# Altium schematic sheet CM001_V4_6.SchDoc(CM001_V4_6)
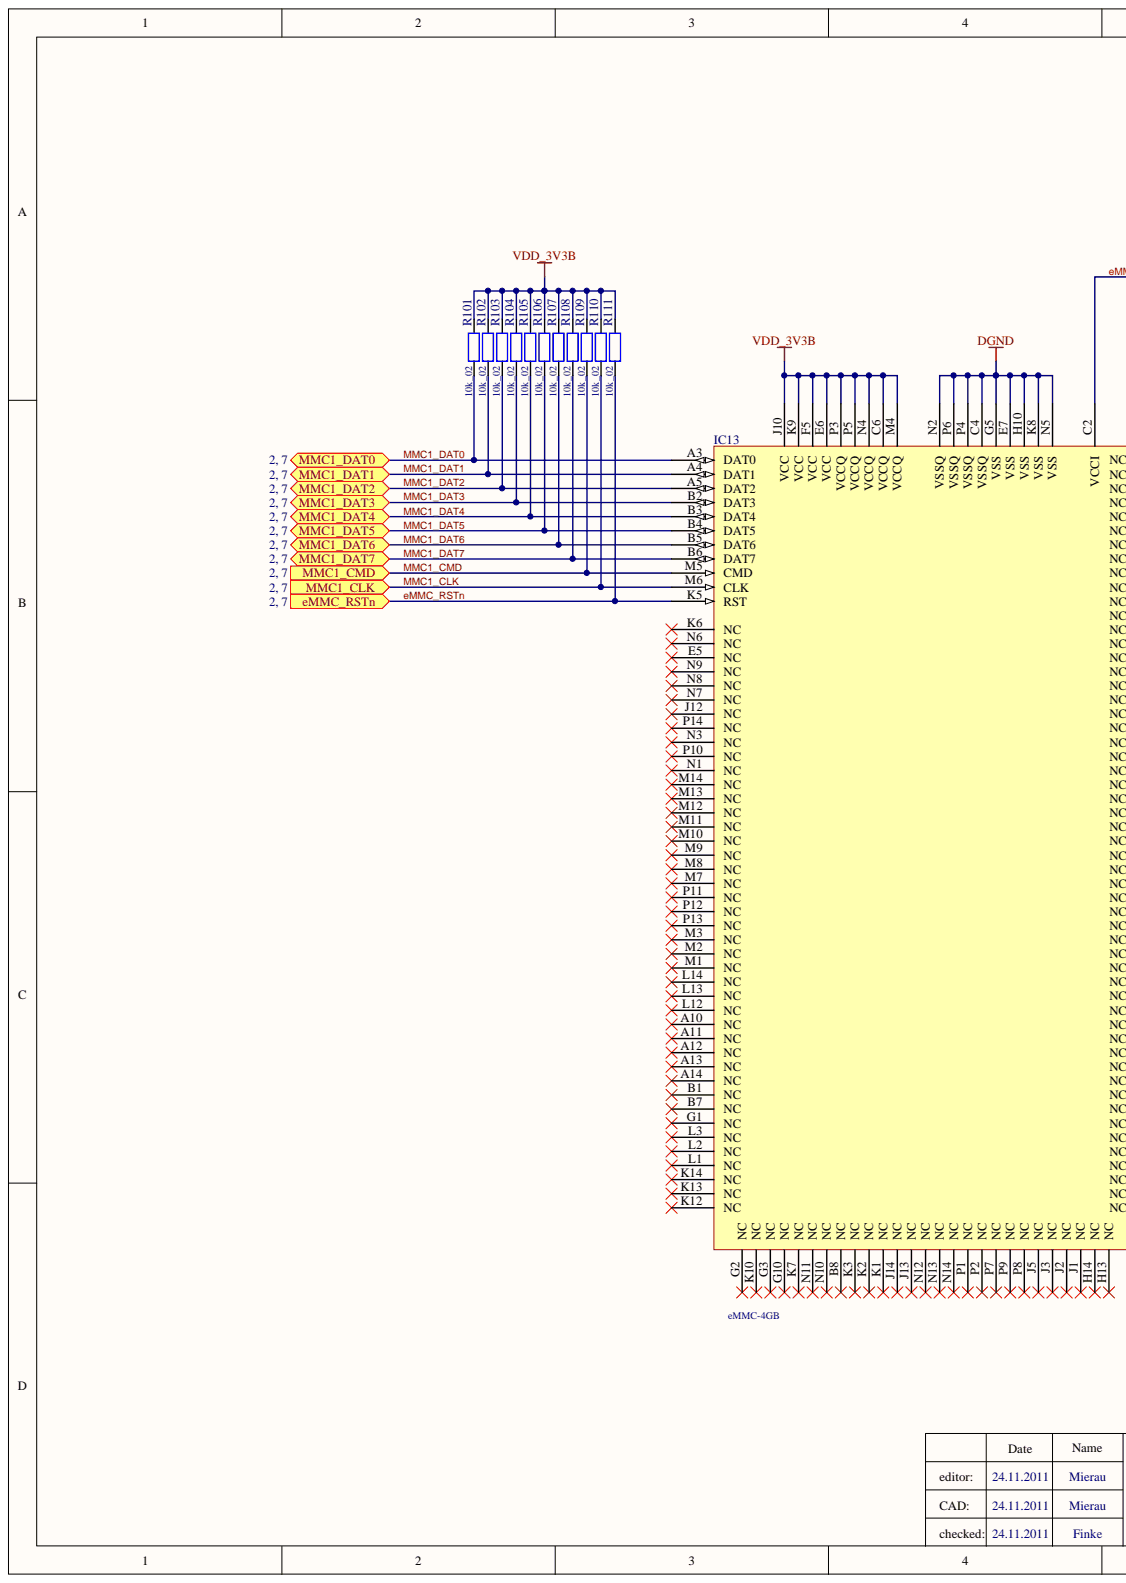
[diagram p0: CM001_V4_6.SchDoc, left]
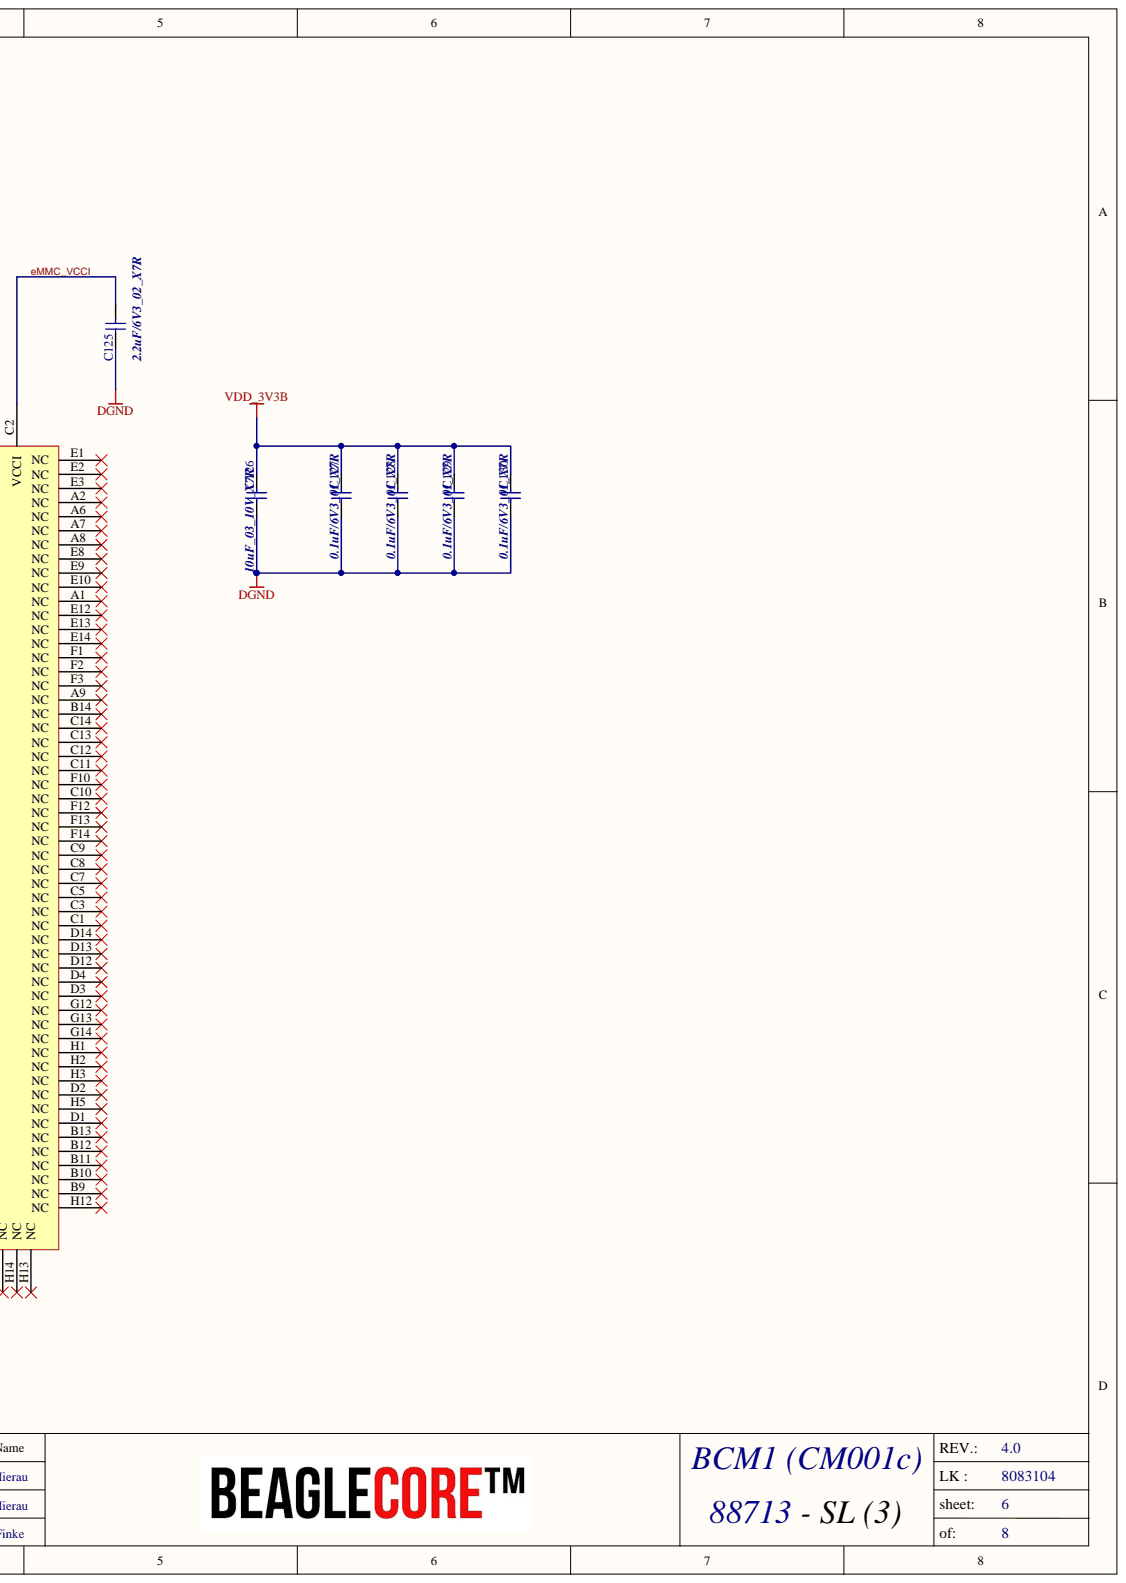
[diagram p1: CM001_V4_6.SchDoc, right]

## Parts
C125  2.2uF/6V3_02_X7R  [CC1005-0402]
C125  2.2uF/6V3_02_X7R  [CC1005-0402]
C126  10uF_03_10V_X7R  [CC1608-0603]
C126  10uF_03_10V_X7R  [CC1608-0603]
C127  0.1uF/6V3_01_X7R  [CC0603-0201]
C127
C128  0.1uF/6V3_01_X7R  [CC0603-0201]
C128
C129  0.1uF/6V3_01_X7R  [CC0603-0201]
C129
C130  0.1uF/6V3_01_X7R  [CC0603-0201]
C130
IC13  eMMC-4GB  [BGA153_P14_P5_11P5X13]
R101  10k_02  [CR1005-0402]
R102  10k_02  [CR1005-0402]
R103  10k_02  [CR1005-0402]
R104  10k_02  [CR1005-0402]
R105  10k_02  [CR1005-0402]
R106  10k_02  [CR1005-0402]
R107  10k_02  [CR1005-0402]
R108  10k_02  [CR1005-0402]
R109  10k_02  [CR1005-0402]
R110  10k_02  [CR1005-0402]
R111  10k_02  [CR1005-0402]

## Ports
eMMC_RSTn, MMC1_CLK, MMC1_CMD, MMC1_DAT0, MMC1_DAT1, MMC1_DAT2, MMC1_DAT3, MMC1_DAT4, MMC1_DAT5, MMC1_DAT6, MMC1_DAT7

## Nets
DGND: C125.1 C126.1 C127.1 C128.1 C129.1 C130.1 IC13.C4(VSSQ) IC13.E7(VSS) IC13.G5(VSS) IC13.H10(VSS) IC13.K8(VSS) IC13.N2(VSSQ) IC13.N5(VSS) IC13.P4(VSSQ) IC13.P6(VSSQ)
VDD_3V3B: C126.2 C127.2 C128.2 C129.2 C130.2 IC13.C6(VCCQ) IC13.E6(VCC) IC13.F5(VCC) IC13.J10(VCC) IC13.K9(VCC) IC13.M4(VCCQ) IC13.N4(VCCQ) IC13.P3(VCCQ) IC13.P5(VCCQ) R101.1 R102.1 R103.1 R104.1 R105.1 R106.1 R107.1 R108.1 R109.1 R110.1 R111.1
eMMC_RSTn: IC13.K5(RST) R111.2 ; port eMMC_RSTn
eMMC_VCCI: C125.2 IC13.C2(VCCI)
MMC1_CLK: IC13.M6(CLK) R110.2 ; port MMC1_CLK
MMC1_CMD: IC13.M5(CMD) R109.2 ; port MMC1_CMD
MMC1_DAT0: IC13.A3(DAT0) R101.2 ; port MMC1_DAT0
MMC1_DAT1: IC13.A4(DAT1) R102.2 ; port MMC1_DAT1
MMC1_DAT2: IC13.A5(DAT2) R103.2 ; port MMC1_DAT2
MMC1_DAT3: IC13.B2(DAT3) R104.2 ; port MMC1_DAT3
MMC1_DAT4: IC13.B3(DAT4) R105.2 ; port MMC1_DAT4
MMC1_DAT5: IC13.B4(DAT5) R106.2 ; port MMC1_DAT5
MMC1_DAT6: IC13.B5(DAT6) R107.2 ; port MMC1_DAT6
MMC1_DAT7: IC13.B6(DAT7) R108.2 ; port MMC1_DAT7

Not connected: IC13 A1(NC) A2(NC) A6(NC) A7(NC) A8(NC) A9(NC) A10(NC) A11(NC) A12(NC) A13(NC) A14(NC) B1(NC) B7(NC) B8(NC) B9(NC) B10(NC) B11(NC) B12(NC) B13(NC) B14(NC) C1(NC) C3(NC) C5(NC) C7(NC) C8(NC) C9(NC) C10(NC) C11(NC) C12(NC) C13(NC) C14(NC) D1(NC) D2(NC) D3(NC) D4(NC) D12(NC) D13(NC) D14(NC) E1(NC) E2(NC) E3(NC) E5(NC) E8(NC) E9(NC) E10(NC) E12(NC) E13(NC) E14(NC) F1(NC) F2(NC) F3(NC) F10(NC) F12(NC) F13(NC) F14(NC) G1(NC) G2(NC) G3(NC) G10(NC) G12(NC) G13(NC) G14(NC) H1(NC) H2(NC) H3(NC) H5(NC) H12(NC) H13(NC) H14(NC) J1(NC) J2(NC) J3(NC) J5(NC) J12(NC) J13(NC) J14(NC) K1(NC) K2(NC) K3(NC) K6(NC) K7(NC) K10(NC) K12(NC) K13(NC) K14(NC) L1(NC) L2(NC) L3(NC) L12(NC) L13(NC) L14(NC) M1(NC) M2(NC) M3(NC) M7(NC) M8(NC) M9(NC) M10(NC) M11(NC) M12(NC) M13(NC) M14(NC) N1(NC) N3(NC) N6(NC) N7(NC) N8(NC) N9(NC) N10(NC) N11(NC) N12(NC) N13(NC) N14(NC) P1(NC) P2(NC) P7(NC) P8(NC) P9(NC) P10(NC) P11(NC) P12(NC) P13(NC) P14(NC)

## Library data of the parts
C125 = C2.2uF/6V3_02_X7R: Capacitor 0402 6V3 X7R; S/T: SMD; Art-Nr.: 08.0002.; erstellt von: Fin; Value: 2.2uF/6V3_02_X7R; Simulation: CAP; Signal Integrity: Cap; Revision: July-2002: Re-released for DXP Platform.; Hersteller: *; Component Kind: Standard; Bestellbezeichnung: *; Library Name: C_ies_Integrated_Library.IntLib
C125 = C2.2uF/6V3_02_X7R: Capacitor 0402 6V3 X7R; S/T: SMD; erstellt von: Fin; Art-Nr.: 08.0002.; Value: 2.2uF/6V3_02_X7R; Simulation: CAP; Signal Integrity: Cap; Revision: July-2002: Re-released for DXP Platform.; Hersteller: *; Component Kind: Standard; Bestellbezeichnung: *; Library Name: C_ies_Integrated_Library.IntLib
C126 = C10uF_03_10V_X7R: Capacitor 0603 10uF 10V X7R; S/T: SMD; erstellt von: MIE; Art-Nr.: 08.0001.0___; Hinweis: KRU Artikelnummer gelöscht; Value: 10uF_03_10V_X7R; Simulation: CAP; Signal Integrity: Cap; Revision: July-2002: Re-released for DXP Platform.; Hersteller: *; Component Kind: Standard; Bestellbezeichnung: *; Library Name: C_ies_Integrated_Library.IntLib
C126 = C10uF_03_10V_X7R: Capacitor 0603 10uF 10V X7R; S/T: SMD; Art-Nr.: 08.0001.0___; Hinweis: KRU Artikelnummer gelöscht; Value: 10uF_03_10V_X7R; Simulation: CAP; Signal Integrity: Cap; Hersteller: *; Component Kind: Standard; Bestellbezeichnung: *; Library Name: C_ies_Integrated_Library.IntLib
C127-C130 = C0.1uF/6V3_01_X7R: Capacitor 0201 6,3V X7R; S/T: SMD; Hersteller: any; erstellt von: Finke; Art-Nr.: 08.0002.0___; Produktbeispiel: Gudeco: muRata: GRM033R70J103JA01D; Value: 0.1uF/6V3_01_X7R; Supplier Part Number 2: 8USl_ZajxFVxkJsfRx-2TMMxBpVDD3GHQuOpPGq7oYPTEIlO4Ffw3x4_A6bjf4Mm; Supplier Part Number 1: 490-3167-6-ND; Supplier 2: Arrow; Supplier 1: Digi-Key; Component Kind: Standard; Bestellbezeichnung: *; Library Name: C_ies_Integrated_Library.IntLib
IC13 = ICeMMC-4GB: Flash Card 4G-byte 3.3V MultiMedia Card 153-Pin WFBGA; S/T: SMD; Lieferant: memphis; Hersteller2: Micron Technology; Hersteller1: Kingston; Hersteller: Hynix; erstellt von: MIE; Bestellbezeichnung2: MTFC4GLDEA 0M WT; Bestellbezeichnung1: KE4CN2H5A-A58; Bestellbezeichnung: H26M31001HPR; Art-Nr.: 04.0701.; Value: eMMC-4GB; Supplier Part Number 1: MTFC4GLDEA-0M WT TR-ND; Supplier 1: Digi-Key; ComponentLink3Description: Datenblatt Micron; ComponentLink2Description: Datenblatt Kingston; ComponentLink1Description: Datenblatt Hynix; Component Kind: Standard; Library Name: IC_ies_Integrated_Library.IntLib; ComponentLink3URL: U:\IC_Integrierte Bauteile\micron\Micron-MTFC2GMVEA-0M_WT-datasheet.pdf; ComponentLink1URL: u:\IC_Integrierte Bauteile\Hynix\SK hynix 1xnm_32Gb based 4GB_Rev1 0.pdf
R101-R111 = R10k_02: Resistor; 0402; 1%; S/T: SMD; Art-Nr.: 09.0002.0004; Value: 10k_02; Supplier Part Number 2: Digi-Key - 311-10.0KLRCT-ND; Supplier Part Number 1: 311-10.0KLRCT-ND; Supplier 2: Octopart; Supplier 1: Digi-Key; Component Kind: Standard; Library Name: R_ies_Integrated_Library.IntLib
